# Altium schematic sheet 02_Power.SchDoc(02_Power)
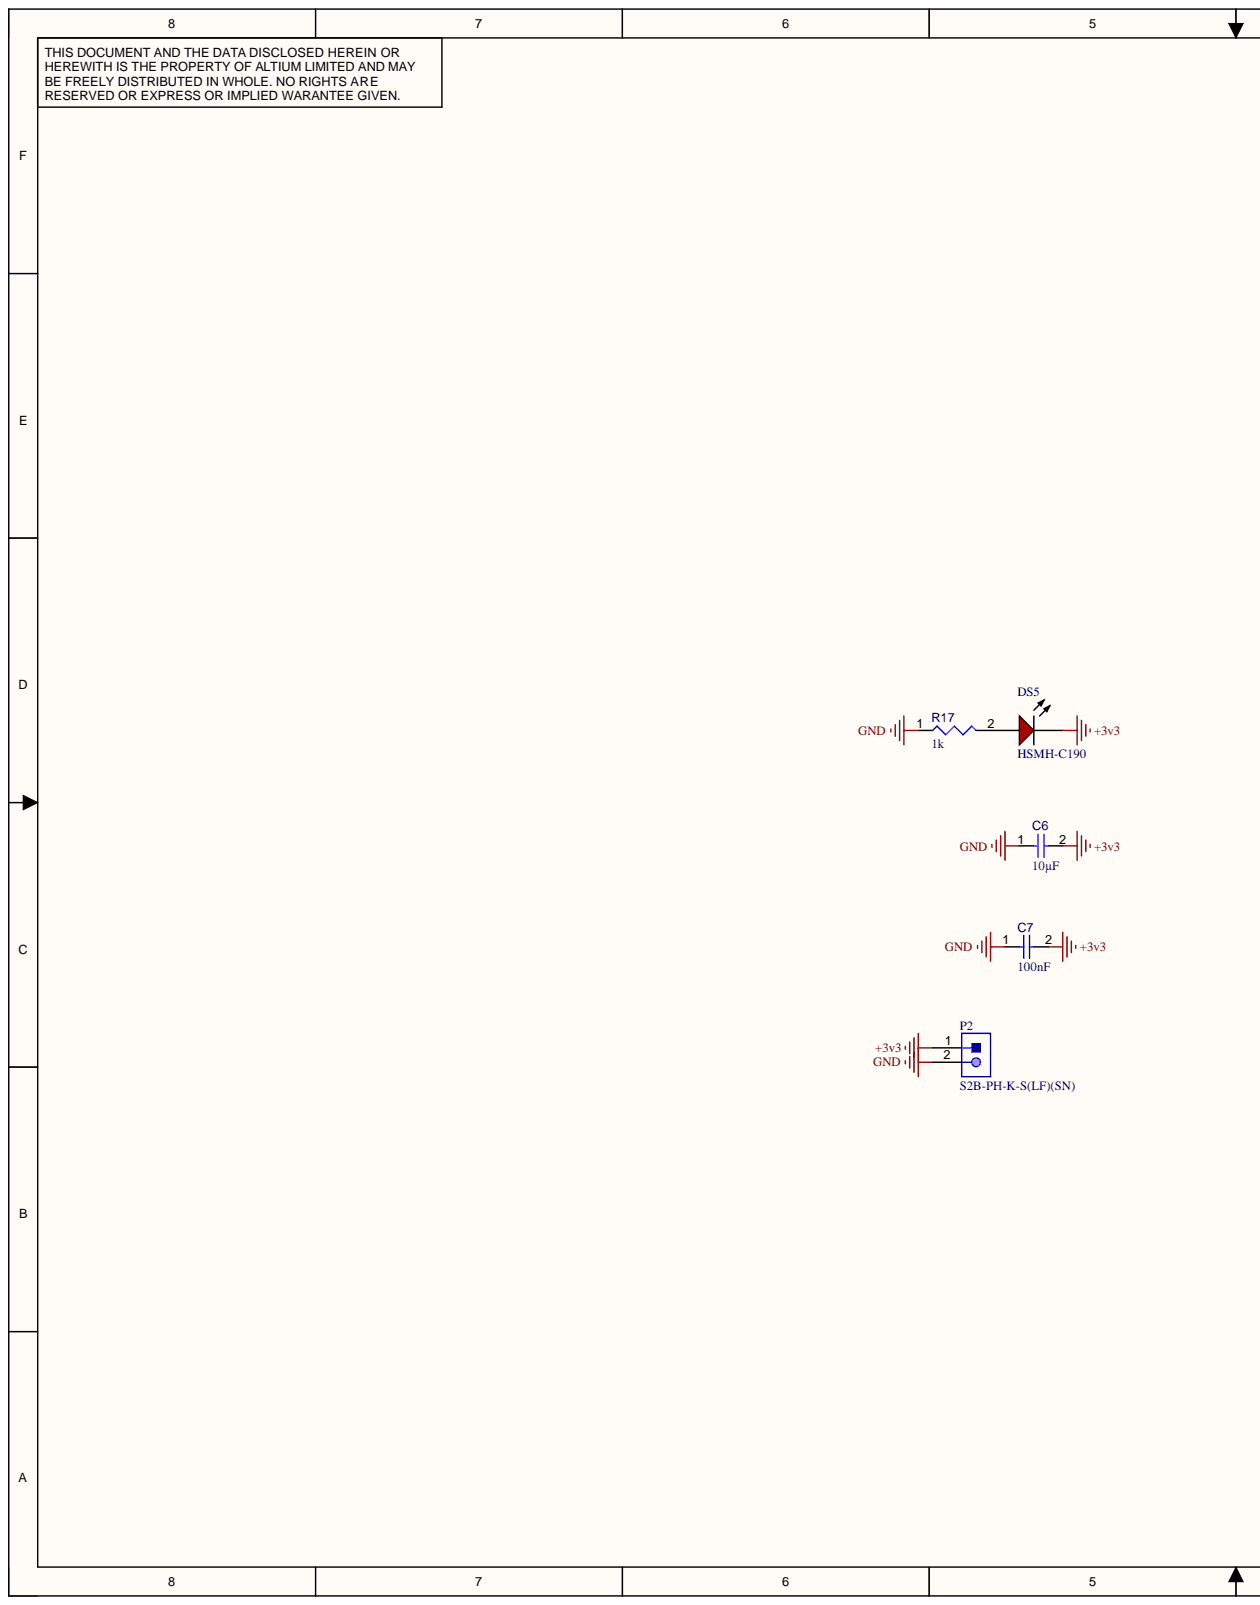
[diagram p0: 02_Power.SchDoc, left]
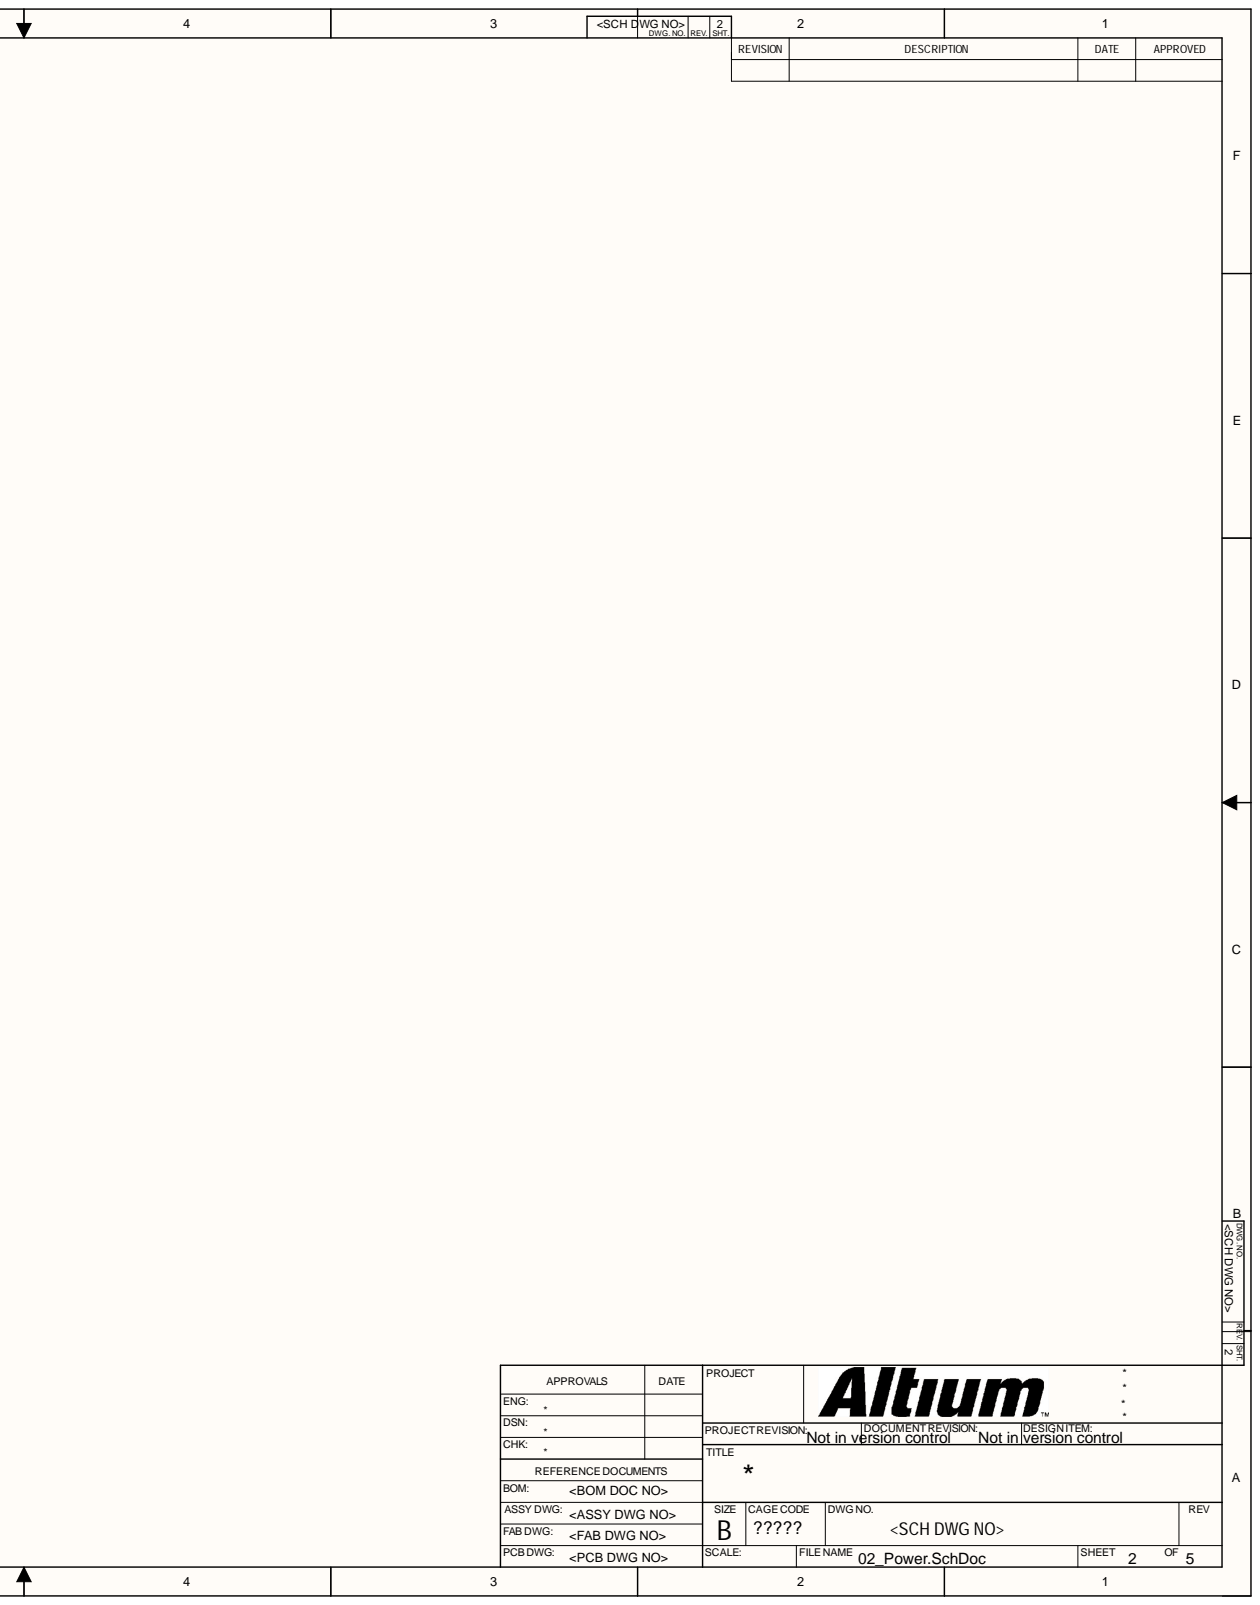
[diagram p1: 02_Power.SchDoc, right]

## Parts
C6  value 10µF  [CAPC2013X135X45NL10T25]  (hidden comment: Capacitor 10 uF +/- 10% 16 V 0805)
C7  value 100nF  [CAPC2013X95X50LL10T25]  (hidden comment: Capacitor 100 nF +/-10% 50 V 0805)
DS5  HSMH-C190  [AVAG-HSMX-C190_V]
P2  S2B-PH-K-S(LF)(SN)  [JST-S2B-PH-K-S_V]
R17  value 1k  [RESC0402(1005)_L]  (hidden comment: 1KR2J)

## Nets
+3v3: C6.2 C7.2 DS5.2 P2.1
GND: C6.1 C7.1 P2.2 R17.1
NetDS5_1: DS5.1 R17.2

## Library data of the parts
C6 = CMP-001-00015-6: Chip Capacitor, 10 uF, +/- 10%, 16 V, 0805 (2012 Metric); Capacitor Type: Ceramic; Voltage Rating: 16V; Case/Package: 0805; Component Kind: Standard; Max Operating Temperature: 85°C; Min Operating Temperature: -55°C; Mounting Technology: SM; Pins: 2; RoHS Compliant: True; Tolerance: 10%; Library Name: Oluwasholape-daniel Oyemade
C7 = CMP-001-00018-6: Chip Capacitor, 100 nF, +/- 10%, 50 V, 0805 (2012 Metric); Capacitor Type: Ceramic; Voltage Rating: 50V; Case/Package: 0805; Component Kind: Standard; Max Operating Temperature: 125°C; Min Operating Temperature: -55°C; Mounting Technology: SM; Pins: 2; RoHS Compliant: True; Tolerance: 10%; Library Name: Oluwasholape-daniel Oyemade
DS5 = CMP-007-00014-2: LED Uni-Color Red, 65 mW, 20 mA, -40 to 85 degC, 2-Pin SMD, RoHS, Tape and Reel; Manufacturer: Avago; Forward Current: 20mA; Forward Voltage: 1.8V; Lens Style: Diffused; Luminous Intensity: 17mcd; Power: 78mW; Viewing Angle: 170degrees; Case/Package: 0603; Colour: Red; Component Kind: Standard; Datasheet URL: http://docs.avagotech.com/docs/AV02-0551EN; Datasheet Version: Mar-12; Illumination Colour: Red; Manufacturer URL: http://www.avagotech.com/; Max Operating Temperature: 85°C; Min Operating Temperature: -40°C; Mounting Technology: SM; Nominal Vf: 1.8V; Package Description: 2-Pin Surface Mount Device, Body 1.6 x 0.8 mm, Height 0.9 mm; Package Quantity: 4000; Package Reference: HSMX-C190; Packaging: TapeandReel; Pins: 2; RoHS Compliant: True; Library Name: Oluwasholape-daniel Oyemade
P2 = CMP-002-00030-2: Male Header, Pitch 2 mm, 1 x 2 Position, Height 4.8 mm, Tail Length 3.4 mm, -25 to 85 degC, RoHS, Bulk; Manufacturer: Japan Solderless Terminals; Case/Package: S2B-PH-K-S; Component Kind: Standard; Connector Type: Header; Datasheet URL: http://www.jst-mfg.com/product/pdf/eng/ePH.pdf; Datasheet Version: Dec-12; Gender: Male; Max Operating Temperature: 85°C; Min Operating Temperature: -25°C; Mounting Technology: SM; Package Description: Male Header, Pitch 2 mm, 1 x 2 Position, Height 4.8 mm, Tail Length 3.4 mm; Package Reference: S2B-PH-K-S; RoHS Compliant: Unknown; Library Name: Oluwasholape-daniel Oyemade
R17 = CMP-009-00075-2: 1K 0.063W 5% 0402 (1005 Metric)  SMD; Power: 63mW; Case/Package: 0402; Component Kind: Standard; Mounting Technology: SMT; Pins: 2; Tolerance: 5%; Library Name: Oluwasholape-daniel Oyemade
